# Altium schematic sheet [04] - MICROCONTROLLER.SchDoc
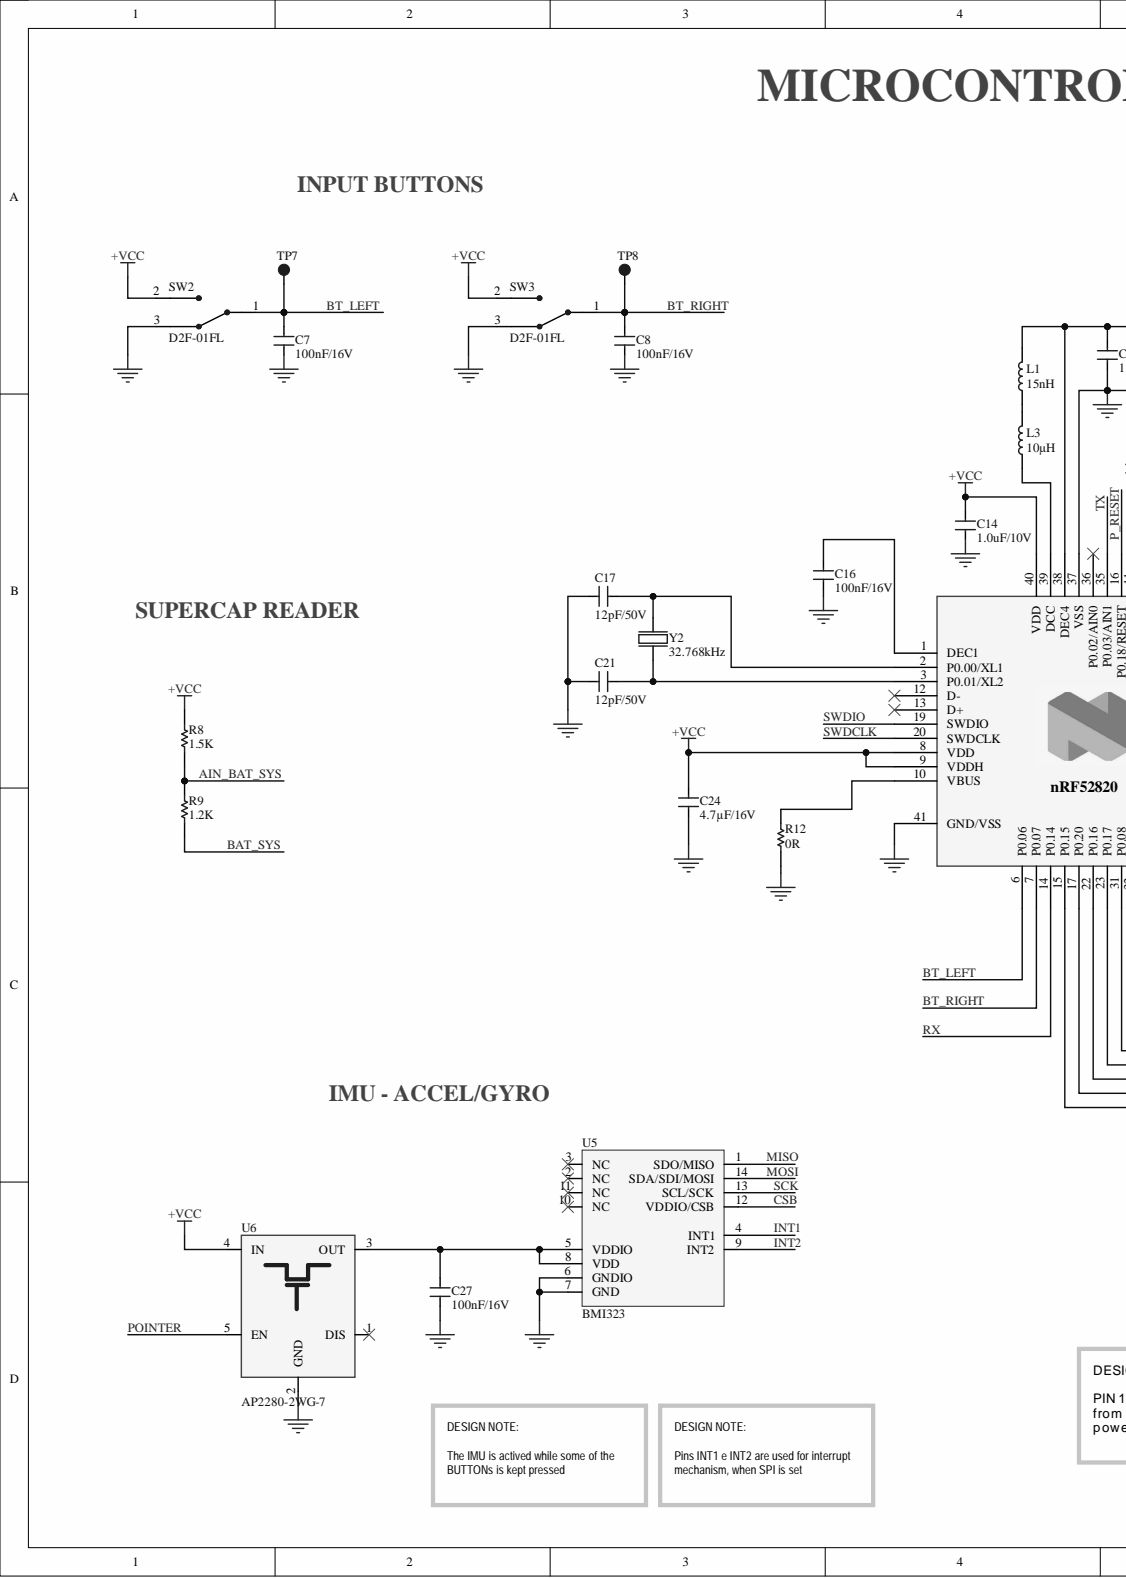
[diagram p0: [04] - MICROCONTROLLER.SchDoc, left]
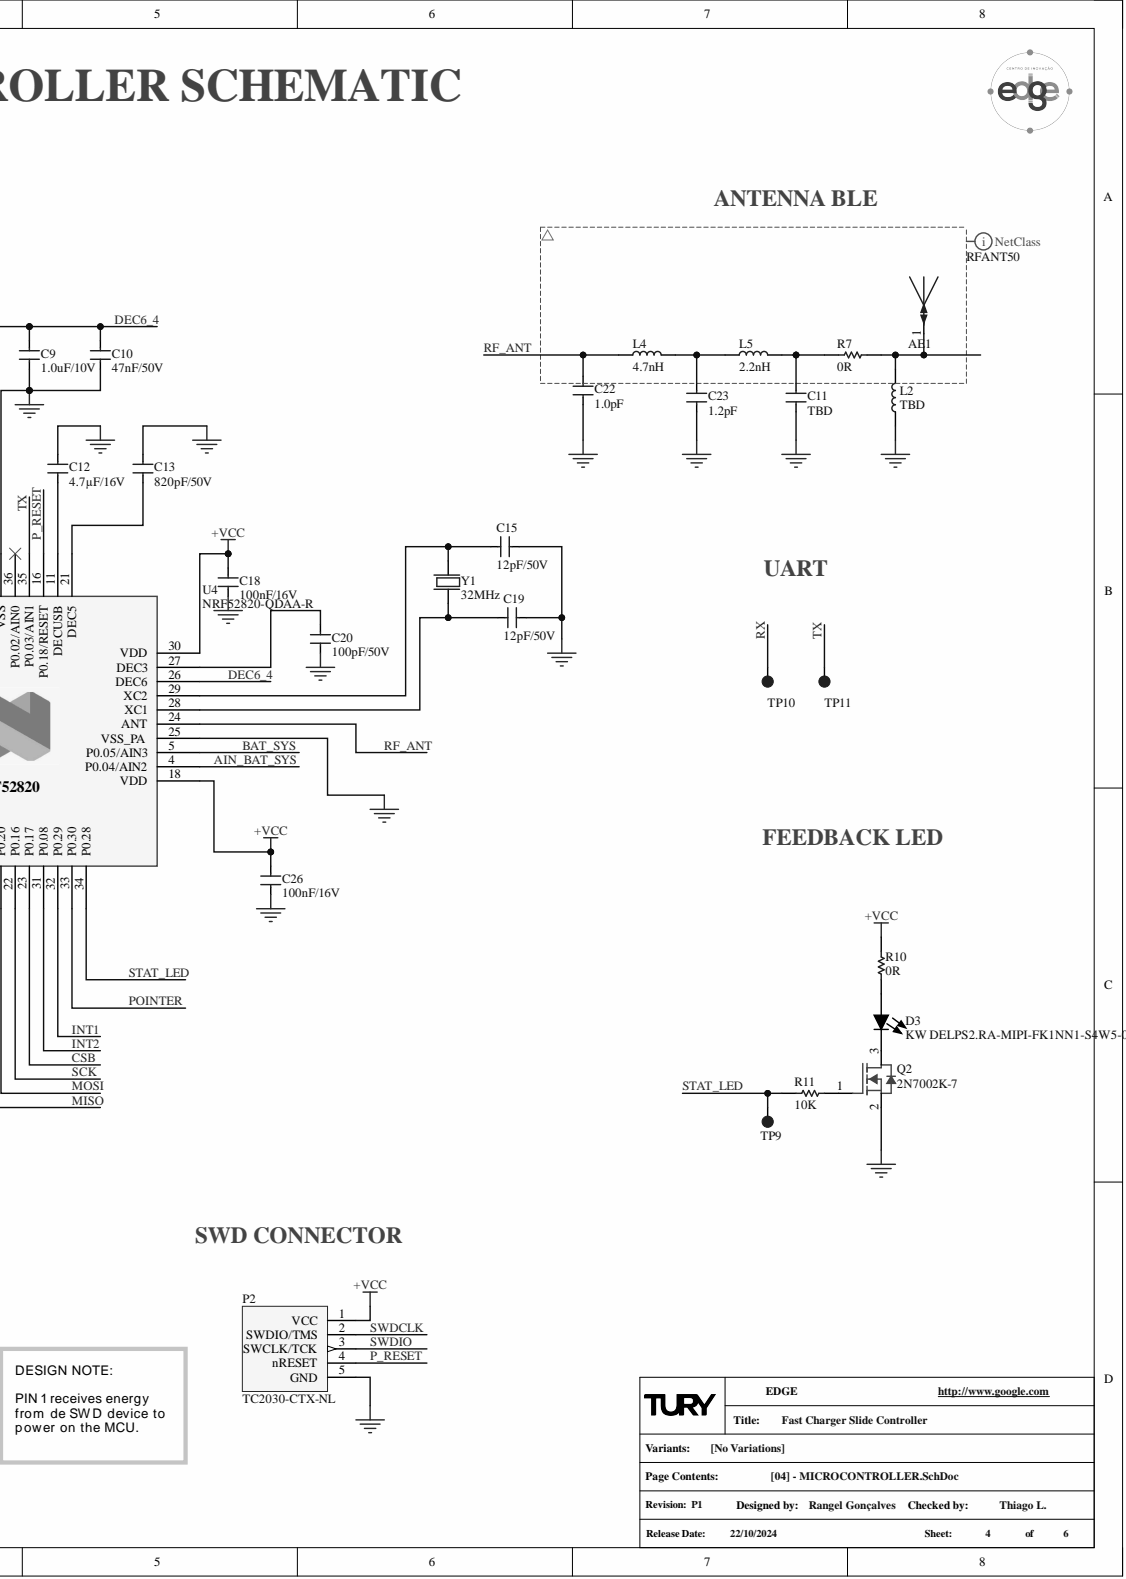
[diagram p1: [04] - MICROCONTROLLER.SchDoc, right]

## Parts
AE1  2450AT45A100E  [2450AT45A100E]  (value not drawn)
C7  100nF/16V  [C0402]
C8  100nF/16V  [C0402]
C9  1.0uF/10V  [C0402]
C10  47nF/50V  [C0402]
C11  TBD  [C0402]
C12  4.7µF/16V  [C0402]
C13  820pF/50V  [C0402]
C14  1.0uF/10V  [C0402]
C15  12pF/50V  [C0402]
C16  100nF/16V  [C0402]
C17  12pF/50V  [C0402]
C18  100nF/16V  [C0402]
C19  12pF/50V  [C0402]
C20  100pF/50V  [C0402]
C21  12pF/50V  [C0402]
C22  1.0pF  [C0402]
C23  1.2pF  [C0402]
C24  4.7µF/16V  [C0402]
C26  100nF/16V  [C0402]
C27  100nF/16V  [C0402]
D3  KW DELPS2.RA-MIPI-FK1NN1-S4W5-0.5-R18  [KW-DELPS2-RA]
L1  15nH  [L0402]
L2  TBD  [L0402]
L3  10µH  [L0603]
L4  4.7nH  [L0402]
L5  2.2nH  [L0402]
P2  TC2030-CTX-NL  [CONN_5X1]  (no BOM)
Q2  2N7002K-7  [SOT90P240X115-3N]
R7  0R  [R0402]
R8  1.5K  [R0402]
R9  1.2K  [R0402]
R10  0R  [R0402]
R11  10K  [R0402]
R12  0R  [R0402]
SW2  D2F-01FL  [D2F-01F]
SW3  D2F-01FL  [D2F-01F]
TP7  BT_RIGHT  [Test Point]  (value not drawn)  (no BOM)
TP8  BT_LEFT  [Test Point]  (value not drawn)  (no BOM)
TP9  STAT_LED  [Test Point]  (no BOM)
TP10  RX  [Test Point]  (no BOM)
TP11  TX  [Test Point]  (no BOM)
U4  NRF52820-QDAA-R  [QFN40P500X500X50_HS-41N]
U5  BMI323  [BMI323]
U6  AP2280-2WG-7  [SOT95P285X145-5N]
Y1  32MHz  [ECS-384-CDX-1983]
Y2  32.768kHz  [ECS-.327-9-34B-TR]

## Nets
+VCC: C14.2 C18.2 C24.2 C26.2 P2.1(VCC) R8.2 R10.2 SW2.2 SW3.2 U4.8(VDD) U4.9(VDDH) U4.18(VDD) U4.30(VDD) U4.40(VDD) U6.4(IN)
GND: C7.1 C8.1 C9.2 C10.1 C11.1 C12.1 C13.2 C14.1 C15.2 C16.1 C17.2 C18.1 C19.2 C20.2 C21.2 C22.1 C23.1 C24.1 C26.1 C27.1 L2.1 P2.5(GND) Q2.2 R12.1 SW2.3 SW3.3 U4.25(VSS_PA) U4.37(VSS) U4.41(GND/VSS) U5.6(GNDIO) U5.7(GND) U6.2(GND)
AIN_BAT_SYS: R8.1 R9.2 U4.4(P0.04/AIN2)
BAT_SYS: R9.1 U4.5(P0.05/AIN3)
BT_LEFT: C7.2 SW2.1 TP7.1 U4.6(P0.06)
BT_RIGHT: C8.2 SW3.1 TP8.1 U4.7(P0.07)
CSB: U4.23(P0.17) U5.12(VDDIO/CSB)
DEC6_4: C9.1 C10.2 L1.2 U4.26(DEC6) U4.38(DEC4)
INT1: U4.32(P0.29) U5.4(INT1)
INT2: U4.31(P0.08) U5.9(INT2)
MISO: U4.15(P0.15) U5.1(SDO/MISO)
MOSI: U4.17(P0.20) U5.14(SDA/SDI/MOSI)
P_RESET: P2.4(nRESET) U4.16(P0.18/RESET)
POINTER: U4.33(P0.30) U6.5(EN)
RF_ANT: C22.2 L4.1 U4.24(ANT)
RX: TP10.1 U4.14(P0.14)
SCK: U4.22(P0.16) U5.13(SCL/SCK)
STAT_LED: R11.1 TP9.1 U4.34(P0.28)
SWDCLK: P2.2(SWDIO/TMS) U4.20(SWDCLK)
SWDIO: P2.3(SWCLK/TCK) U4.19(SWDIO)
TX: TP11.1 U4.35(P0.03/AIN1)
NetAE1_1: AE1.1 L2.2 R7.1
NetC11_2: C11.2 L5.2 R7.2
NetC12_2: C12.2 U4.11(DECUSB)
NetC13_1: C13.1 U4.21(DEC5)
NetC15_1: C15.1 U4.29(XC2) Y1.1
NetC16_2: C16.2 U4.1(DEC1)
NetC17_1: C17.1 U4.2(P0.00/XL1) Y2.1
NetC19_1: C19.1 U4.28(XC1) Y1.3
NetC20_1: C20.1 U4.27(DEC3)
NetC21_1: C21.1 U4.3(P0.01/XL2) Y2.2
NetC23_2: C23.2 L4.2 L5.1
NetC27_2: C27.2 U5.5(VDDIO) U5.8(VDD) U6.3(OUT)
NetD3_1: D3.1 R10.1
NetD3_2: D3.2 Q2.3
NetL1_1: L1.1 L3.2
NetL3_1: L3.1 U4.39(DCC)
NetQ2_1: Q2.1 R11.2
NetR12_2: R12.2 U4.10(VBUS)

Not connected: U4 12(D-) 13(D+) 36(P0.02/AIN0); U5 2(NC) 3(NC) 10(NC) 11(NC); U6 1(DIS)
